# Altium schematic sheet BrewTempController_12V.SchDoc
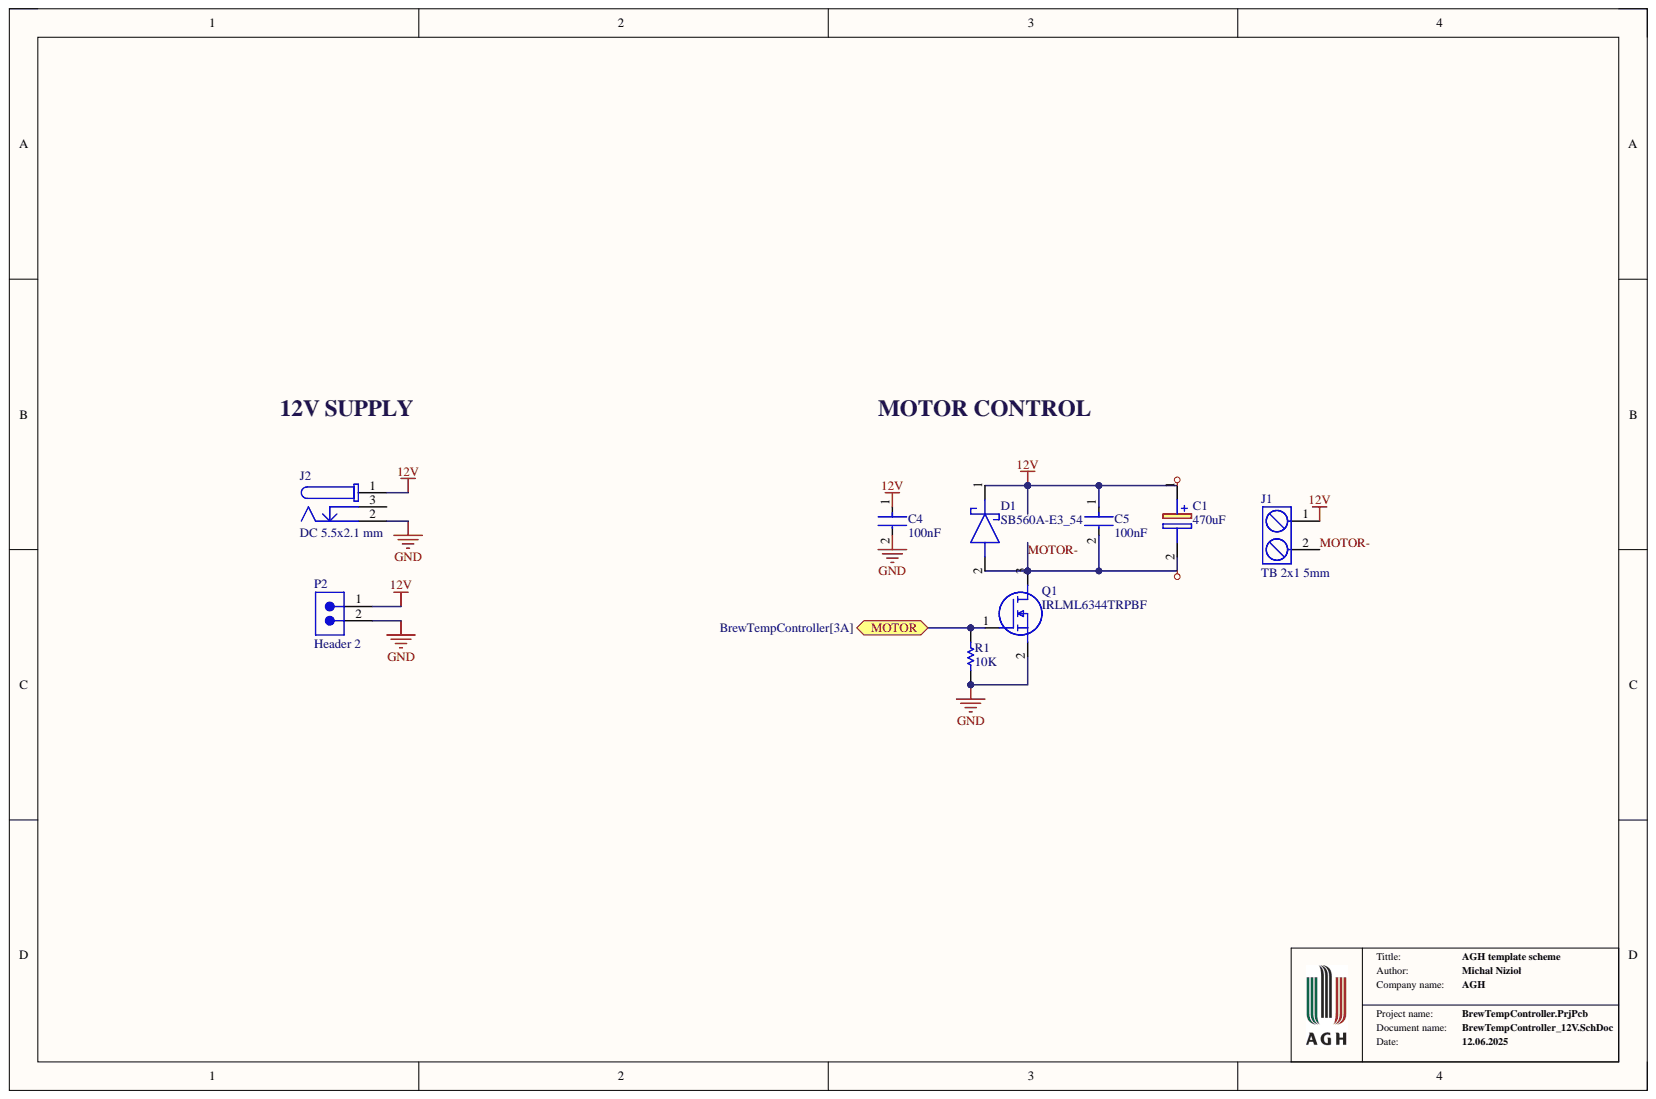
[diagram p0: BrewTempController_12V.SchDoc]

## Parts
C1  470uF  [CAPPRD500W60D1300H2200]
C4  100nF  [FP-0805-L_2_01_0_2-W_1_25-MFG]
C5  100nF  [FP-0805-L_2_01_0_2-W_1_25-MFG]
D1  SB560A-E3_54  [DIOAD2855W132L835D505]
J1  TB 2x1 5mm  [TB_2x1_5mm]
J2  DC 5.5x2.1 mm  [694106301002]
P2  Header 2  [SMTC-TSW-102-26-XX-S]
Q1  IRLML6344TRPBF  [SOT95P237X112-3N]
R1  10K  [RESC2013X60X30NL20T20]

## Ports
MOTOR

## Nets
12V: C1.1 C4.1 C5.1 D1.1 J1.1 J2.1 P2.1
GND: C4.2 J2.2 P2.2 Q1.2 R1.1
MOTOR: Q1.1 R1.2 ; port MOTOR
MOTOR-: C1.2 C5.2 D1.2 J1.2 Q1.3

Not connected: J2 3

## Library data of the parts
C1 = EST477M050AL3AA: Capacitor Polarised EST477M050AL3AA; Manufacturer_Name: KEMET; Arrow Part Number: EST477M050AL3AA; Arrow Price/Stock: https://www.arrow.com/en/products/est477m050al3aa/kemet-corporation?region=nac; Component Kind: Standard; Datasheet Link: https://content.kemet.com/datasheets/KEM_A4010_EST.pdf; Height: 22mm; Manufacturer_Part_Number: EST477M050AL3AA; Mouser Part Number: 80-EST477M050AL3AA; Mouser Price/Stock: https://www.mouser.co.uk/ProductDetail/KEMET/EST477M050AL3AA?qs=T9vdlufmP8wwlV2BdbJhWw%3D%3D
C4 C5 = CMP-2007-04725-2: Automotive Ceramic Capacitor, 0805, 100nF, 5%, X7R, 15%, 50V; Dissipation Factor: 2.5%; Capacitance: 100nF; Case Code (Imperial): 0805; Case Code (Metric): 2012; Case/Package: 0805; Component Kind: Standard; Construction: Flat; Contact Plating: Tin; Depth: 1.25mm; Dielectric: X7R; Height: 0.94mm; Lead Free: Lead Free; Length: 2.01mm; Library Name: Altium Content Vault; Max Operating Temperature: 125°C; Min Operating Temperature: -55°C; Mount: Surface Mount; Number of Pins: 2; Package Quantity: 4000; Packaging: Tape and Reel; Radiation Hardening: No; Ratings: AEC-Q200; RoHS Compliant: Yes; Series: -; Termination: SMD/SMT; Thickness: 0.037inch; Tolerance: 5%; Voltage Rating: 50V; Voltage Rating (DC): 50V; Width: 0.049inch
D1 = SB560A-E3_54: Schottky Diode; Manufacturer_Name: Vishay; Manufacturer_Part_Number: SB560A-E3/54; Arrow Part Number: SB560A-E3/54; Arrow Price/Stock: https://www.arrow.com/en/products/sb560a-e354/vishay?utm_currency=USD&region=nac; Component Kind: Standard; Datasheet Link: https://www.vishay.com/docs/88903/sb520a.pdf; Mouser Part Number: 625-SB560A-E3; Mouser Price/Stock: https://www.mouser.co.uk/ProductDetail/Vishay-General-Semiconductor/SB560A-E3-54?qs=u91jd7aysvPYACG%2FTLlP7g%3D%3D
J1 = TB_2x1_5mm: Terminal block (ARK connector) 2x1 5mm; Component Kind: Standard; Library Name: Schlib_AGH.SchLib
J2 = CMP-1502-00188-2: 694106301002; NexarPartId: 52676100; Connector Type: Power; Current Rating: 5A; Gender: Male; Insulation Resistance: 100MΩ; Number of Contacts: 3; Operating Supply Voltage: 24V; Shielding: Unshielded; Voltage Rating (DC): 20V; Color: Black; Component Kind: Standard; Contact Gender: Female; Contact Material: Gold, Tin; Contact Plating: Tin; Flammability Rating: UL94 V-0; Height: 11mm; Housing Material: Polyamide; Library Name: Altium Content Vault; Max Operating Temperature: 85°C; Min Operating Temperature: -40°C; Mount: Through Hole; Packaging: Bulk; REACH SVHC: Unknown; RoHS: Compliant; Termination: Through Hole; Voltage Rating: 24V
P2 = CMP-1024-00329-1: 0.025" SQ Post Header, Through-hole, Vertical, -55 to 125 degC, 2.54 mm Pitch, 2-Pin, Male, RoHS; Manufacturer: Samtec; PartNumber: TSW-102-26-F-S; Pitch: 2.54 mm; Component Kind: Standard; ComponentLink1Description: Manufacturer URL; ComponentLink1URL: http://www.samtec.com; ComponentLink2Description: Datasheet; ComponentLink2URL: http://www.samtec.com/documents/webfiles/pdf/TSW_TH.PDF; ComponentLink3Description: Package Specification; ComponentLink3URL: http://www.samtec.com/documents/webfiles/cpdf/TSW-XXX-XX-XXX-X-XX-XXX-MKT.pdf; DatasheetVersion: F-214; Library Name: Altium Content Vault; Mounting Technology: Through-hole; Orientation: Vertical; PackageDescription: .025[0.64] SQ. Post Terminal Strip Assembly; PackageReference: TSW-102-26-XX-S; PackageVersion: DM, 8/1988; RoHS: True; Temperature Range: -55 to 125 degC
Q1 = IRLML6344TRPBF: MOSFET (N-Channel); Manufacturer_Name: Infineon; Arrow Part Number: IRLML6344TRPBF; Arrow Price/Stock: https://www.arrow.com/en/products/irlml6344trpbf/infineon-technologies-ag?utm_currency=USD&region=nac; Component Kind: Standard; Datasheet Link: https://www.infineon.com/dgdl/irlml6344pbf.pdf?fileId=5546d462533600a4015356689c44262c; Height: 1.12mm; Manufacturer_Part_Number: IRLML6344TRPBF; Mouser Part Number: 942-IRLML6344TRPBF; Mouser Price/Stock: https://www.mouser.co.uk/ProductDetail/Infineon-Technologies/IRLML6344TRPBF?qs=9%252BKlkBgLFf2w4qS48UOXVw%3D%3D
R1 = CMP-2001-04657-1: Manufacturer: KOA Speer; Part Number: RK73B2ATTD103J; Component Kind: Standard; Library Name: Altium Content Vault
